# Altium schematic sheet 3.ICM20602.SchDoc(3.ICM20602)
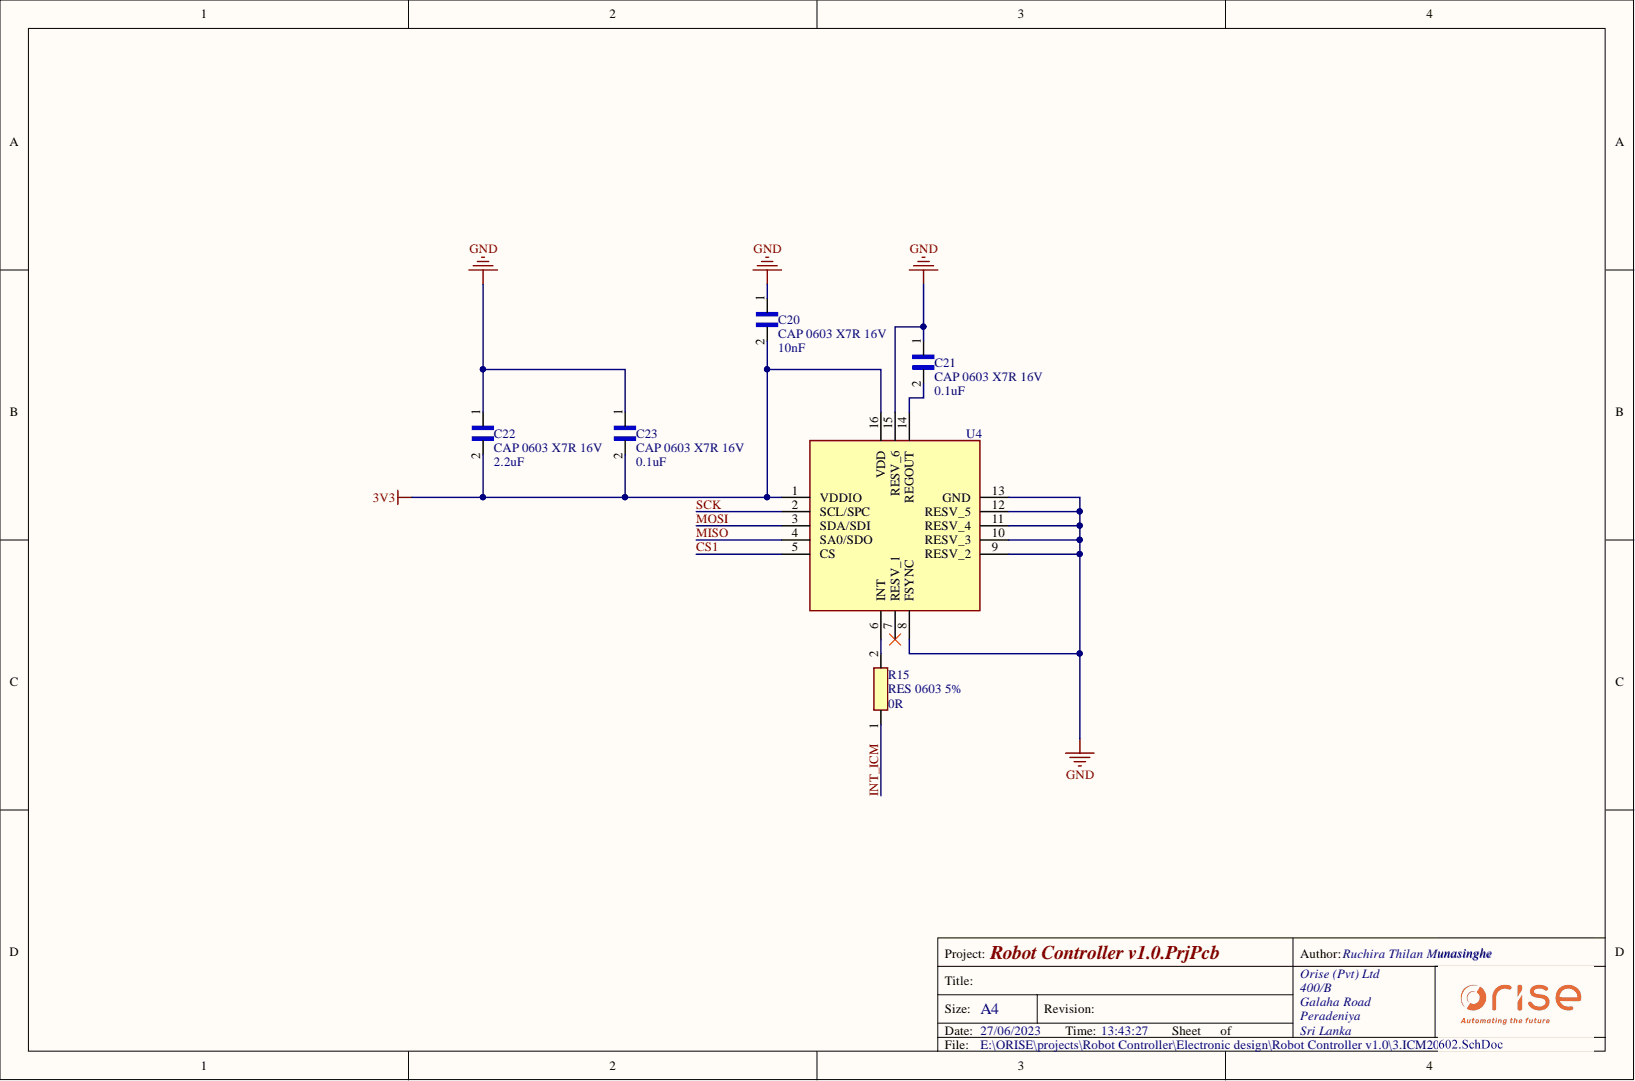
[diagram p0: 3.ICM20602.SchDoc]

## Parts
C20  CAP 0603 X7R 16V  value 10nF  [CAP 0603]
C21  CAP 0603 X7R 16V  value 0.1uF  [CAP 0603]
C22  CAP 0603 X7R 16V  value 2.2uF  [CAP 0603]
C23  CAP 0603 X7R 16V  value 0.1uF  [CAP 0603]
R15  RES 0603 5%  value 0R  [RES 0603]
U4  ICM20602  [ICM20602]

## Nets
3V3: C20.2 C22.2 C23.2 U4.1(VDDIO) U4.16(VDD)
GND: C20.1 C21.1 C22.1 C23.1 U4.8(FSYNC) U4.9(RESV_2) U4.10(RESV_3) U4.11(RESV_4) U4.12(RESV_5) U4.13(GND) U4.15(RESV_6)
CS1: U4.5(CS)
INT_ICM: R15.1
MISO: U4.4(SA0/SDO)
MOSI: U4.3(SDA/SDI)
SCK: U4.2(SCL/SPC)
NetC21_2: C21.2 U4.14(REGOUT)
NetR15_2: R15.2 U4.6(INT)

Not connected: U4 7(RESV_1)

## Library data of the parts
U4 = ICM20602: Integrated Circuit; Library Name: Robot Controller v1.0.SchLib; Component Kind: Standard
C20-C23 = CAP 0603 X7R 16V: Library Name: Robot Controller v1.0.SchLib; Description: CAP 0603 X7R 16V; Component Kind: Standard
R15 = RES 0603 5%: Library Name: Robot Controller v1.0.SchLib; Description: RES 0603 5%; Component Kind: Standard
